# Altium schematic sheet Sheet1.SchDoc
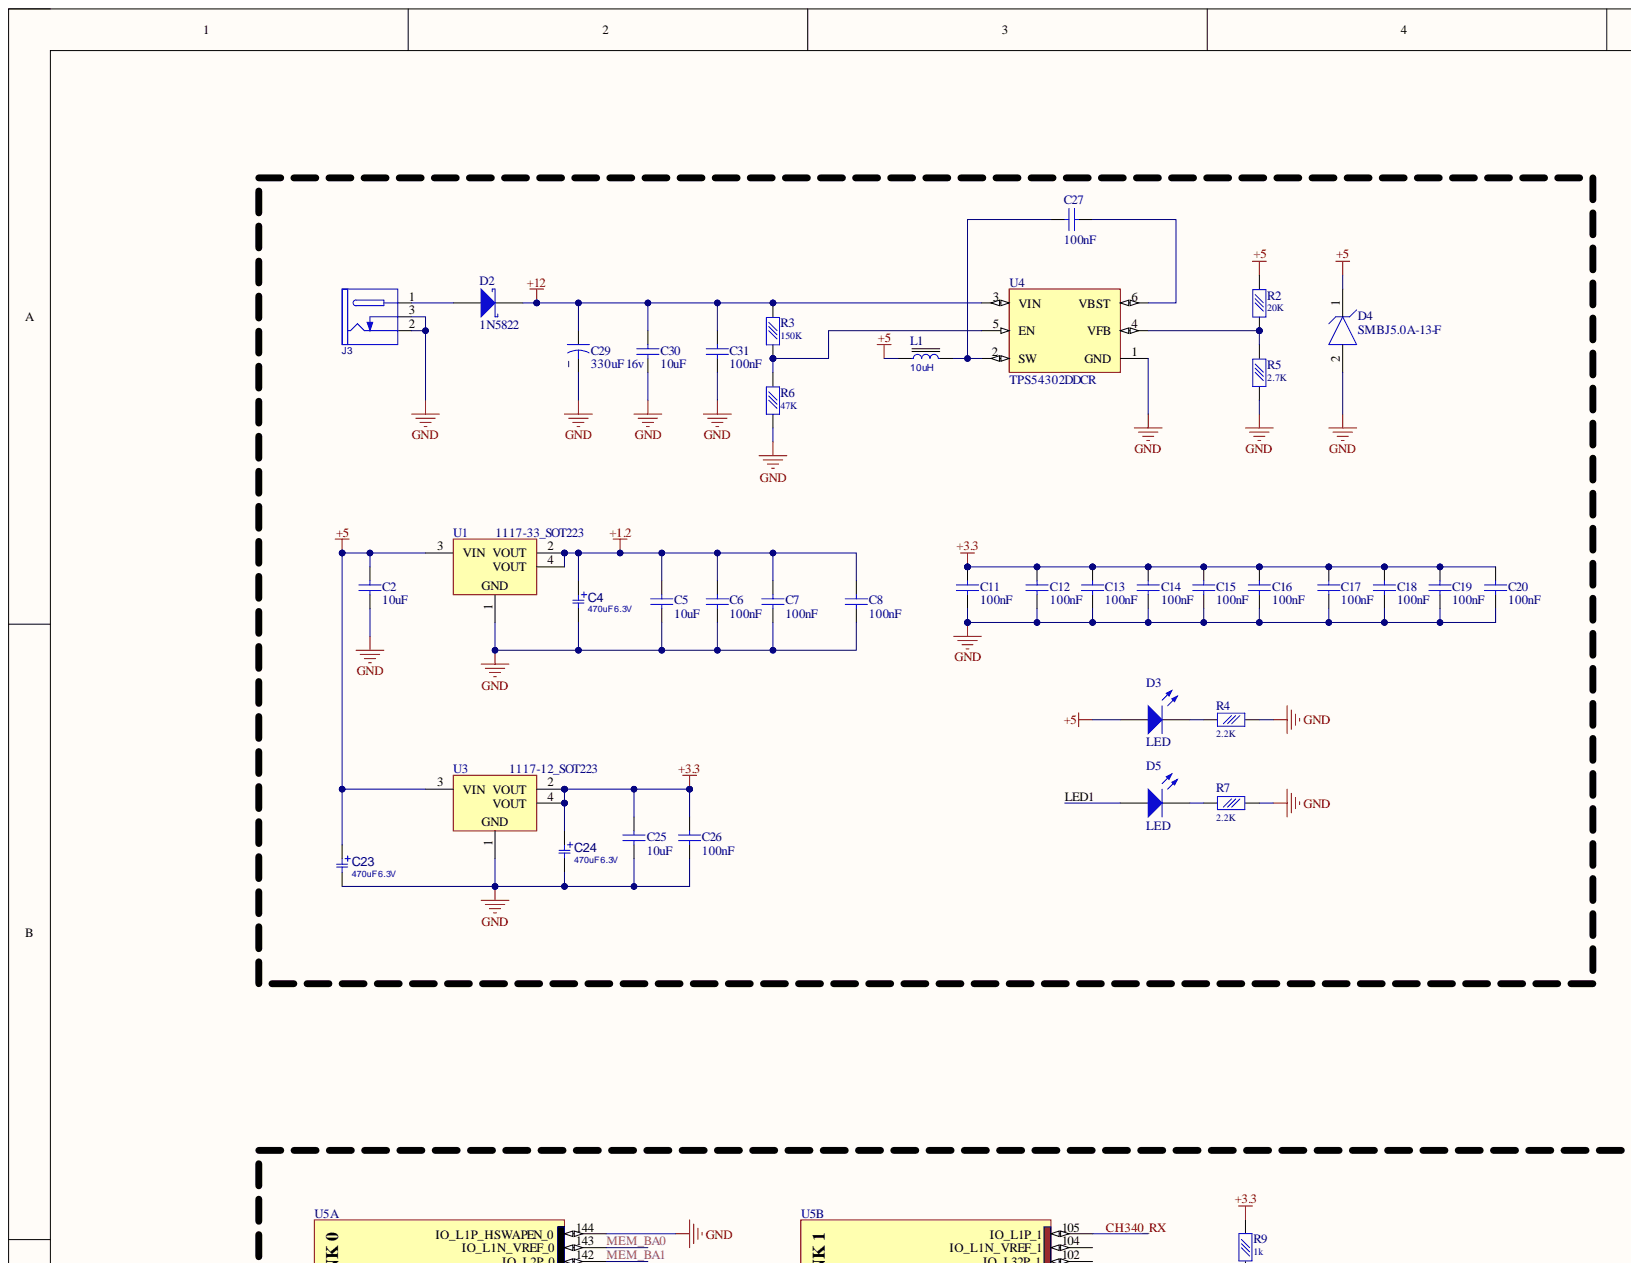
[diagram p0: Sheet1.SchDoc, top left]
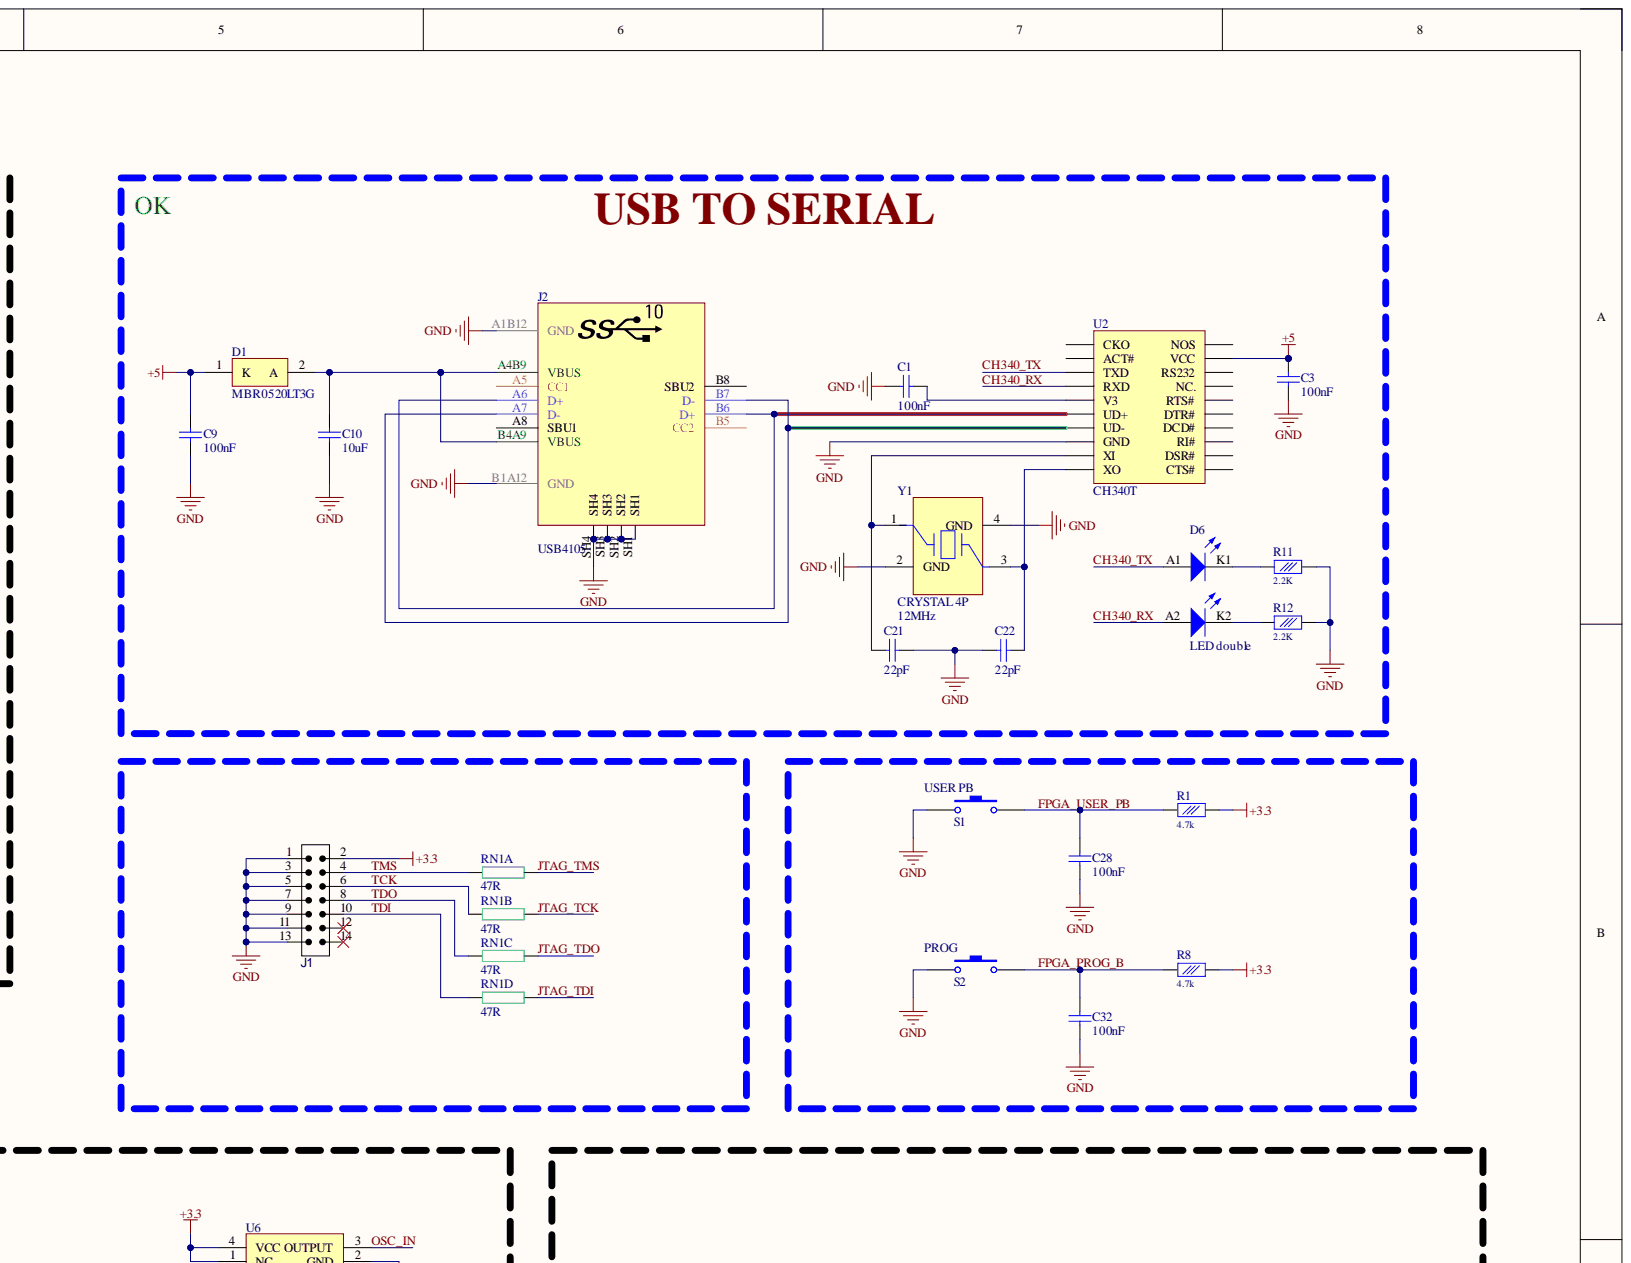
[diagram p1: Sheet1.SchDoc, top right]
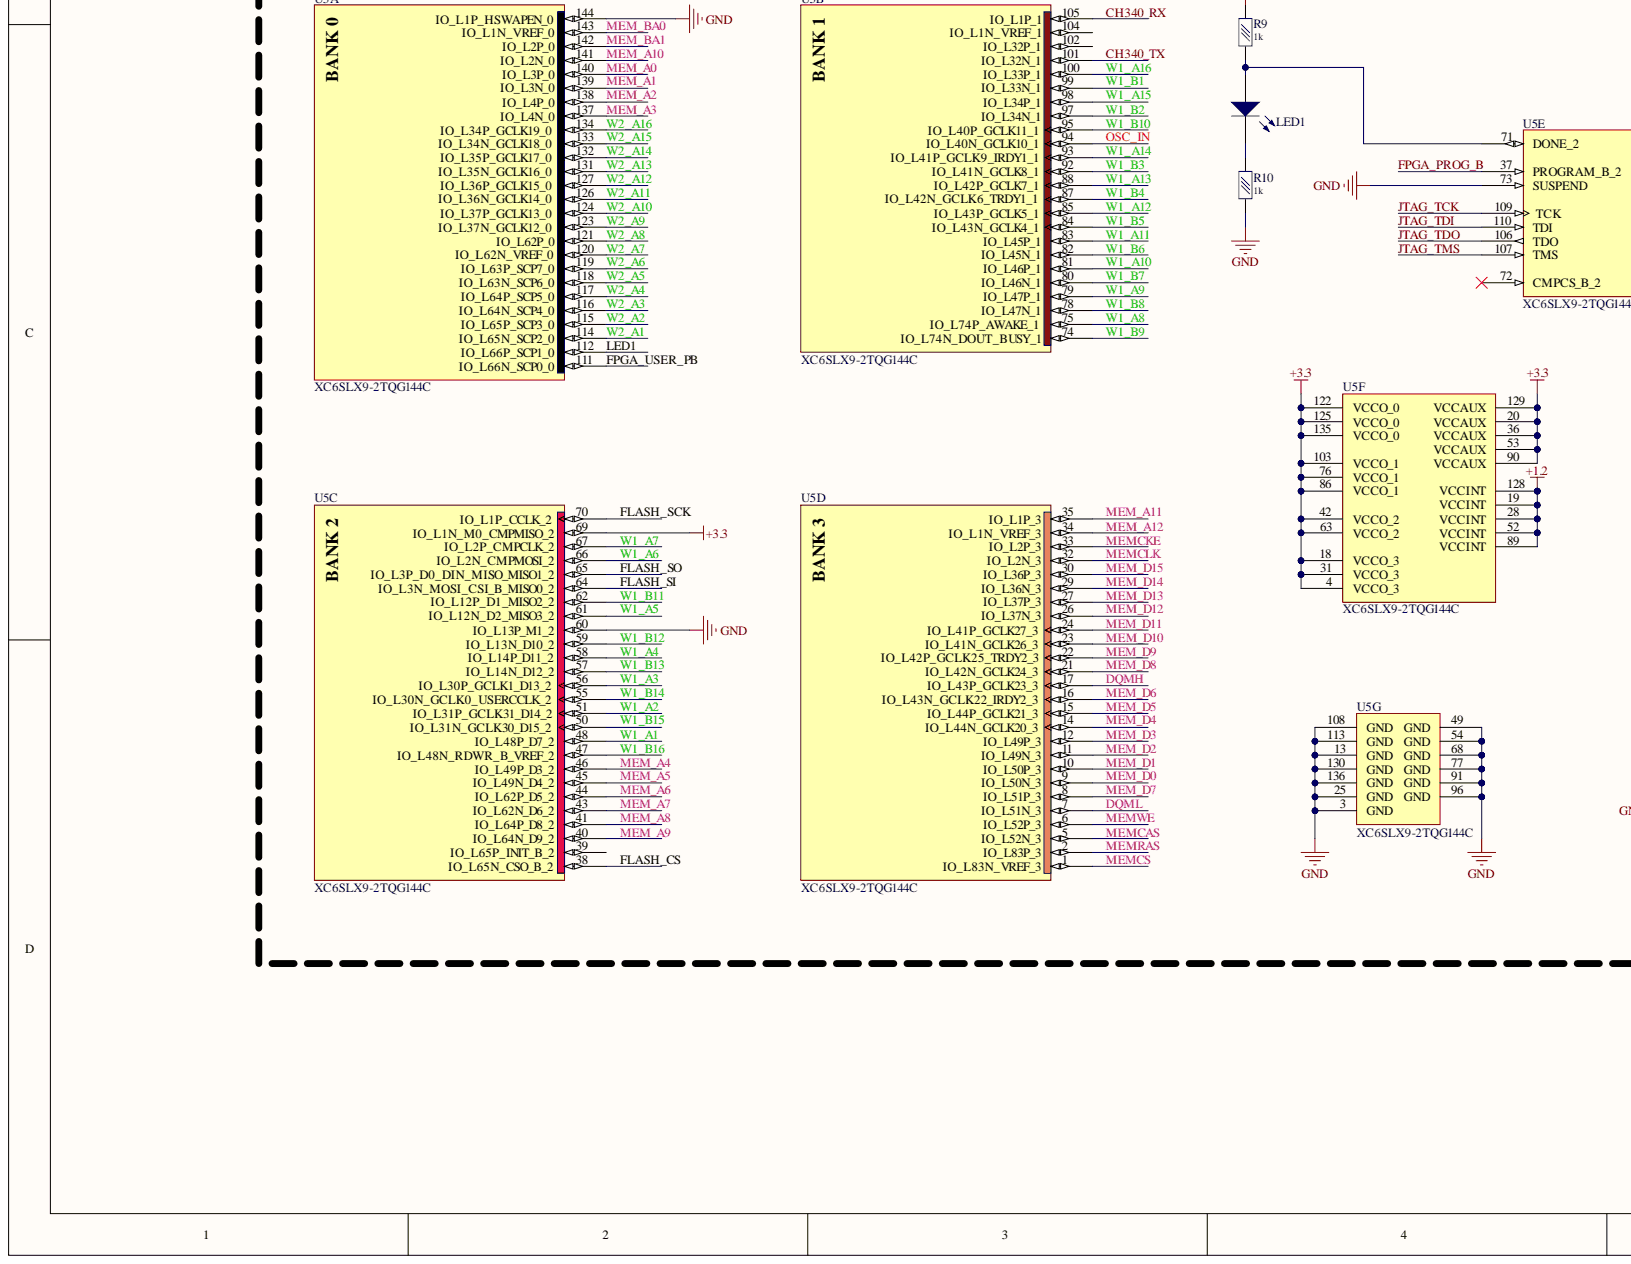
[diagram p2: Sheet1.SchDoc, bottom left]
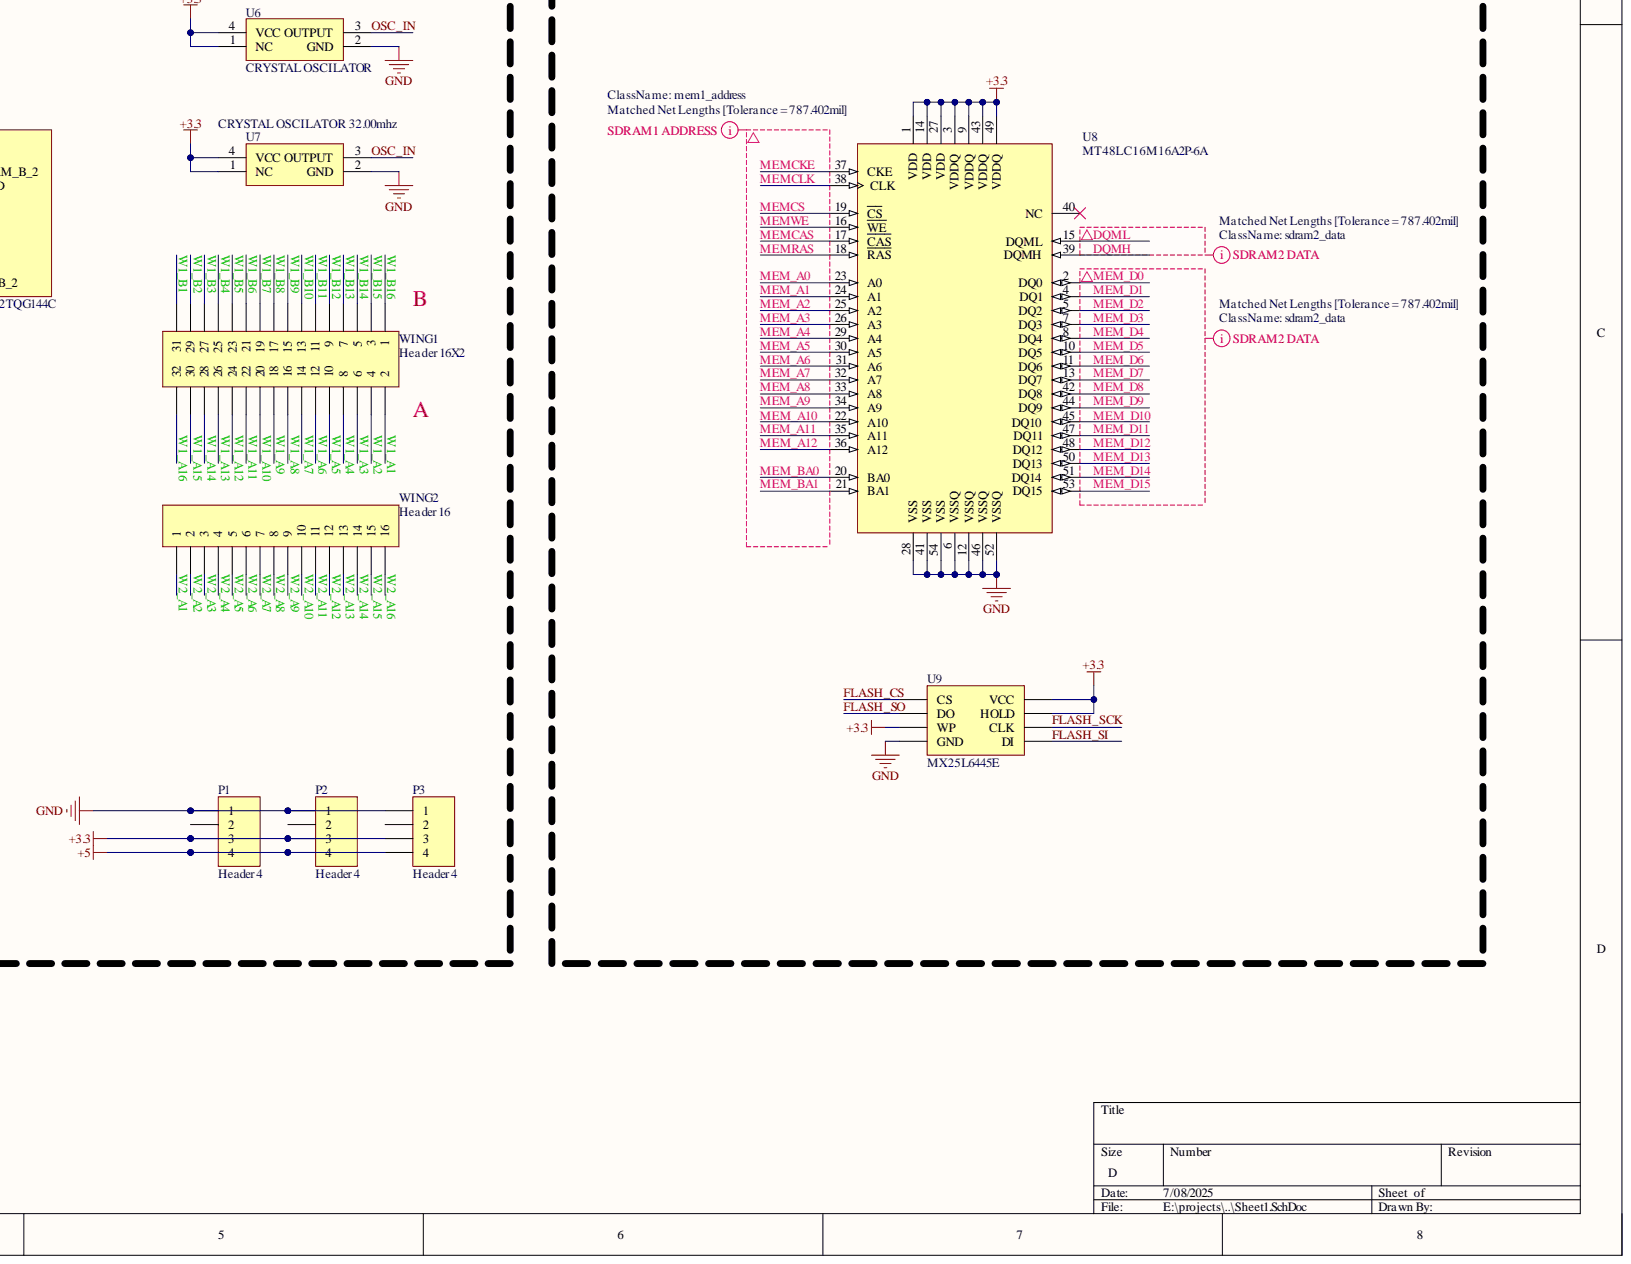
[diagram p3: Sheet1.SchDoc, bottom right]

## Parts
C1  value 100nF  [CAP 0805]  (hidden comment: chip_cap)
C2  value 10uF  [CAP 1206/3216 - 1.0MM]  (hidden comment: chip_cap)
C3  value 100nF  [CAP 0805]  (hidden comment: chip_cap)
C4  470uF 6.3V  [C_SOLID_6X11]
C5  value 10uF  [CAP 1206/3216 - 1.0MM]  (hidden comment: chip_cap)
C6  value 100nF  [0805]  (hidden comment: chip_cap)
C7  value 100nF  [0805]  (hidden comment: chip_cap)
C8  value 100nF  [CAP 0805]  (hidden comment: chip_cap)
C9  value 100nF  [CAP 0805]  (hidden comment: chip_cap)
C10  value 10uF  [CAP 1206/3216 - 1.0MM]  (hidden comment: chip_cap)
C11  value 100nF  [0805]  (hidden comment: chip_cap)
C12  value 100nF  [0805]  (hidden comment: chip_cap)
C13  value 100nF  [CAP 0805]  (hidden comment: chip_cap)
C14  value 100nF  [0805]  (hidden comment: chip_cap)
C15  value 100nF  [0805]  (hidden comment: chip_cap)
C16  value 100nF  [0805]  (hidden comment: chip_cap)
C17  value 100nF  [CAP 0805]  (hidden comment: chip_cap)
C18  value 100nF  [CAP 0805]  (hidden comment: chip_cap)
C19  value 100nF  [CAP 0805]  (hidden comment: chip_cap)
C20  value 100nF  [CAP 0805]  (hidden comment: chip_cap)
C21  value 22pF  [CAP 0603/1608]  (hidden comment: chip_cap)
C22  value 22pF  [CAP 0603/1608]  (hidden comment: chip_cap)
C23  470uF 6.3V  [C_SOLID_6X11]
C24  470uF 6.3V  [C_SOLID_6X11]
C25  value 10uF  [CAP 1206/3216 - 1.0MM]  (hidden comment: chip_cap)
C26  value 100nF  [0805]  (hidden comment: chip_cap)
C27  value 100nF  [CAP 0805]  (hidden comment: chip_cap)
C28  value 100nF  [0805]  (hidden comment: chip_cap)
C29  value 330uF 16v  [CAP-RADIAL-3.2mm]  (hidden comment: CAP)
C30  value 10uF  [CAP 1206/3216 - 1.0MM]  (hidden comment: chip_cap)
C31  value 100nF  [CAP 0805]  (hidden comment: chip_cap)
C32  value 100nF  [0805]  (hidden comment: chip_cap)
D1  MBR0520LT3G  [ONSC-SOD-123-2-425-04_V]
D2  1N5822  [DIOM5028X20N]
D3  LED  [LEDC1608X60N]
D4  SMBJ5.0A-13-F  [SMBJ_A]
D5  LED  [LEDC1608X60N]
D6  LED double  [LEDC1608X60N - double]
J1  IDC_2X7  [IDC_2X7]  (value not drawn)
J2  value USB4105  [USBC_SMD_16_USB4105]  (hidden comment: Debug Port)
J3  DC_POWER_JACK  [JAC_PWR2]  (value not drawn)
L1  10uH  [L_4]
LED1  LED  [LEDC1608X60N]
P1  Header 4  [Header1X4]
P2  Header 4  [Header1X4]
P3  Header 4  [Header1X4]
R1  value 4.7k  [0805]  (hidden comment: chip_res)
R2  value 20K  [RES 0603]  (hidden comment: chip_res)
R3  value 150K  [RES 0603]  (hidden comment: chip_res)
R4  value 2.2K  [RES 0805]  (hidden comment: chip_res)
R5  value 2.7K  [RES 0603]  (hidden comment: chip_res)
R6  value 47K  [RES 0603]  (hidden comment: chip_res)
R7  value 2.2K  [RES 0805]  (hidden comment: chip_res)
R8  value 4.7k  [0805]  (hidden comment: chip_res)
R9  value 1k  [0805]  (hidden comment: chip_res)
R10  value 1k  [0805]  (hidden comment: chip_res)
R11  value 2.2K  [RES 0603]  (hidden comment: chip_res)
R12  value 2.2K  [RES 0603]  (hidden comment: chip_res)
RN1  value 47R  [RESCAXS80P320X160X50-8N]  (hidden comment: ARRAY RES)  (parts A,B,C,D)
S1  USER PB  [tactile-micro-push-button]
S2  PROG  [tactile-micro-push-button]
U1  1117-33_SOT223  [SOT-223_M]
U2  CH340T  [SSOP20_L]
U3  1117-12_SOT223  [SOT-223_M]
U4  TPS54302DDCR  [FP-DDC0006A-IPC_B]
U5  XC6SLX9-2TQG144C  [TQG144_N]  (parts A,B,C,D,E,F,G)
U6  CRYSTAL OSCILATOR  [XTL_4_3225]
U7  CRYSTAL OSCILATOR 32.00mhz  [CRYSTAL OSCILLATOR]
U8  MT48LC16M16A2P-6A  [TSOP2-54_M]
U9  MX25L6445E  [8-pin SOIC 208-mil]
WING1  Header 16X2  [Header2X16]
WING2  Header 16  [Header1X16]
Y1  CRYSTAL 4P  [XTL_4_3225]

## Nets
+1.2: C4.1 C5.2 C6.2 C7.2 C8.2 U1.2(VOUT) U1.4(VOUT) U5.19(VCCINT) U5.28(VCCINT) U5.52(VCCINT) U5.89(VCCINT) U5.128(VCCINT)
+3.3: C11.2 C12.2 C13.2 C14.2 C15.2 C16.2 C17.2 C18.2 C19.2 C20.2 C24.1 C25.2 C26.2 J1.2 P1.3 P2.3 P3.3 R1.1 R8.1 R9.1 U3.2(VOUT) U3.4(VOUT) U5.4(VCCO_3) U5.18(VCCO_3) U5.20(VCCAUX) U5.31(VCCO_3) U5.36(VCCAUX) U5.42(VCCO_2) U5.53(VCCAUX) U5.63(VCCO_2) U5.69(IO_L1N_M0_CMPMISO_2) U5.76(VCCO_1) U5.86(VCCO_1) U5.90(VCCAUX) U5.103(VCCO_1) U5.122(VCCO_0) U5.125(VCCO_0) U5.129(VCCAUX) U5.135(VCCO_0) U6.1(NC) U6.4(VCC) U7.1(NC) U7.4(VCC) U8.1(VDD) U8.3(VDDQ) U8.9(VDDQ) U8.14(VDD) U8.27(VDD) U8.43(VDDQ) U8.49(VDDQ) U9.3(WP) U9.7(HOLD) U9.8(VCC)
+5: C2.2 C3.1 C9.2 C23.1 D1.1 D3.A D4.1 L1.1 P1.4 P2.4 P3.4 R2.2 U1.3(VIN) U2.19(VCC) U3.3(VIN)
+12: C29.1 C30.2 C31.2 D2.2 R3.2 U4.3(VIN)
GND: C1.2 C2.1 C3.2 C4.2 C5.1 C6.1 C7.1 C8.1 C9.1 C10.1 C11.1 C12.1 C13.1 C14.1 C15.1 C16.1 C17.1 C18.1 C19.1 C20.1 C21.2 C22.1 C23.2 C24.2 C25.1 C26.1 C28.1 C29.2 C30.1 C31.1 C32.1 D4.2 J1.1 J1.3 J1.5 J1.7 J1.9 J1.11 J1.13 J2.A1B12(GND) J2.B1A12(GND) J2.SH1 J2.SH2 J2.SH3 J2.SH4 J3.2 J3.3 P1.1 P2.1 P3.1 R4.2 R5.1 R6.1 R7.2 R10.2 R11.2 R12.2 S1.1 S2.1 U1.1 U2.8(GND) U3.1 U4.1(GND) U5.3(GND) U5.13(GND) U5.25(GND) U5.49(GND) U5.54(GND) U5.60(IO_L13P_M1_2) U5.68(GND) U5.73(SUSPEND) U5.77(GND) U5.91(GND) U5.96(GND) U5.108(GND) U5.113(GND) U5.130(GND) U5.136(GND) U5.144(IO_L1P_HSWAPEN_0) U6.2(GND) U7.2(GND) U8.6(VSSQ) U8.12(VSSQ) U8.28(VSS) U8.41(VSS) U8.46(VSSQ) U8.52(VSSQ) U8.54(VSS) U9.4(GND) Y1.2(GND) Y1.4(GND)
CH340_RX: D6.A2(K2) U2.4(RXD) U5.105(IO_L1P_1)
CH340_TX: D6.A1 U2.3(TXD) U5.101(IO_L32N_1)
DQMH: U5.17(IO_L43P_GCLK23_3) U8.39(DQMH)
DQML: U5.7(IO_L51N_3) U8.15(DQML)
FLASH_CS: U5.38(IO_L65N_CSO_B_2) U9.1(CS)
FLASH_SCK: U5.70(IO_L1P_CCLK_2) U9.6(CLK)
FLASH_SI: U5.64(IO_L3N_MOSI_CSI_B_MISO0_2) U9.5(DI)
FLASH_SO: U5.65(IO_L3P_D0_DIN_MISO_MISO1_2) U9.2(DO)
FPGA_PROG_B: C32.2 R8.2 S2.2 U5.37(PROGRAM_B_2)
FPGA_USER_PB: C28.2 R1.2 S1.2 U5.111(IO_L66N_SCP0_0)
JTAG_TCK: RN1.7 U5.109(TCK)
JTAG_TDI: RN1.5 U5.110(TDI)
JTAG_TDO: RN1.6 U5.106(TDO)
JTAG_TMS: RN1.8 U5.107(TMS)
LED1: D5.A U5.112(IO_L66P_SCP1_0)
MEM_A0: U5.140(IO_L3P_0) U8.23(A0)
MEM_A1: U5.139(IO_L3N_0) U8.24(A1)
MEM_A2: U5.138(IO_L4P_0) U8.25(A2)
MEM_A3: U5.137(IO_L4N_0) U8.26(A3)
MEM_A4: U5.46(IO_L49P_D3_2) U8.29(A4)
MEM_A5: U5.45(IO_L49N_D4_2) U8.30(A5)
MEM_A6: U5.44(IO_L62P_D5_2) U8.31(A6)
MEM_A7: U5.43(IO_L62N_D6_2) U8.32(A7)
MEM_A8: U5.41(IO_L64P_D8_2) U8.33(A8)
MEM_A9: U5.40(IO_L64N_D9_2) U8.34(A9)
MEM_A10: U5.141(IO_L2N_0) U8.22(A10)
MEM_A11: U5.35(IO_L1P_3) U8.35(A11)
MEM_A12: U5.34(IO_L1N_VREF_3) U8.36(A12)
MEM_BA0: U5.143(IO_L1N_VREF_0) U8.20(BA0)
MEM_BA1: U5.142(IO_L2P_0) U8.21(BA1)
MEM_D0: U5.9(IO_L50N_3) U8.2(DQ0)
MEM_D1: U5.10(IO_L50P_3) U8.4(DQ1)
MEM_D2: U5.11(IO_L49N_3) U8.5(DQ2)
MEM_D3: U5.12(IO_L49P_3) U8.7(DQ3)
MEM_D4: U5.14(IO_L44N_GCLK20_3) U8.8(DQ4)
MEM_D5: U5.15(IO_L44P_GCLK21_3) U8.10(DQ5)
MEM_D6: U5.16(IO_L43N_GCLK22_IRDY2_3) U8.11(DQ6)
MEM_D7: U5.8(IO_L51P_3) U8.13(DQ7)
MEM_D8: U5.21(IO_L42N_GCLK24_3) U8.42(DQ8)
MEM_D9: U5.22(IO_L42P_GCLK25_TRDY2_3) U8.44(DQ9)
MEM_D10: U5.23(IO_L41N_GCLK26_3) U8.45(DQ10)
MEM_D11: U5.24(IO_L41P_GCLK27_3) U8.47(DQ11)
MEM_D12: U5.26(IO_L37N_3) U8.48(DQ12)
MEM_D13: U5.27(IO_L37P_3) U8.50(DQ13)
MEM_D14: U5.29(IO_L36N_3) U8.51(DQ14)
MEM_D15: U5.30(IO_L36P_3) U8.53(DQ15)
MEMCAS: U5.5(IO_L52N_3) U8.17(CAS)
MEMCKE: U5.33(IO_L2P_3) U8.37(CKE)
MEMCLK: U5.32(IO_L2N_3) U8.38(CLK)
MEMCS: U5.1(IO_L83N_VREF_3) U8.19(CS)
MEMRAS: U5.2(IO_L83P_3) U8.18(RAS)
MEMWE: U5.6(IO_L52P_3) U8.16(WE)
OSC_IN: U5.94(IO_L40N_GCLK10_1) U6.3(OUTPUT) U7.3(OUTPUT)
TCK: J1.6 RN1.2
TDI: J1.10 RN1.4
TDO: J1.8 RN1.3
TMS: J1.4 RN1.1
W1_A1: U5.48(IO_L48P_D7_2) WING1.2
W1_A2: U5.51(IO_L31P_GCLK31_D14_2) WING1.4
W1_A3: U5.56(IO_L30P_GCLK1_D13_2) WING1.6
W1_A4: U5.58(IO_L14P_D11_2) WING1.8
W1_A5: U5.61(IO_L12N_D2_MISO3_2) WING1.10
W1_A6: U5.66(IO_L2N_CMPMOSI_2) WING1.12
W1_A7: U5.67(IO_L2P_CMPCLK_2) WING1.14
W1_A8: U5.75(IO_L74P_AWAKE_1) WING1.16
W1_A9: U5.79(IO_L47P_1) WING1.18
W1_A10: U5.81(IO_L46P_1) WING1.20
W1_A11: U5.83(IO_L45P_1) WING1.22
W1_A12: U5.85(IO_L43P_GCLK5_1) WING1.24
W1_A13: U5.88(IO_L42P_GCLK7_1) WING1.26
W1_A14: U5.93(IO_L41P_GCLK9_IRDY1_1) WING1.28
W1_A15: U5.98(IO_L34P_1) WING1.30
W1_A16: U5.100(IO_L33P_1) WING1.32
W1_B1: U5.99(IO_L33N_1) WING1.31
W1_B2: U5.97(IO_L34N_1) WING1.29
W1_B3: U5.92(IO_L41N_GCLK8_1) WING1.27
W1_B4: U5.87(IO_L42N_GCLK6_TRDY1_1) WING1.25
W1_B5: U5.84(IO_L43N_GCLK4_1) WING1.23
W1_B6: U5.82(IO_L45N_1) WING1.21
W1_B7: U5.80(IO_L46N_1) WING1.19
W1_B8: U5.78(IO_L47N_1) WING1.17
W1_B9: U5.74(IO_L74N_DOUT_BUSY_1) WING1.15
W1_B10: U5.95(IO_L40P_GCLK11_1) WING1.13
W1_B11: U5.62(IO_L12P_D1_MISO2_2) WING1.11
W1_B12: U5.59(IO_L13N_D10_2) WING1.9
W1_B13: U5.57(IO_L14N_D12_2) WING1.7
W1_B14: U5.55(IO_L30N_GCLK0_USERCCLK_2) WING1.5
W1_B15: U5.50(IO_L31N_GCLK30_D15_2) WING1.3
W1_B16: U5.47(IO_L48N_RDWR_B_VREF_2) WING1.1
W2_A1: U5.114(IO_L65N_SCP2_0) WING2.1
W2_A2: U5.115(IO_L65P_SCP3_0) WING2.2
W2_A3: U5.116(IO_L64N_SCP4_0) WING2.3
W2_A4: U5.117(IO_L64P_SCP5_0) WING2.4
W2_A5: U5.118(IO_L63N_SCP6_0) WING2.5
W2_A6: U5.119(IO_L63P_SCP7_0) WING2.6
W2_A7: U5.120(IO_L62N_VREF_0) WING2.7
W2_A8: U5.121(IO_L62P_0) WING2.8
W2_A9: U5.123(IO_L37N_GCLK12_0) WING2.9
W2_A10: U5.124(IO_L37P_GCLK13_0) WING2.10
W2_A11: U5.126(IO_L36N_GCLK14_0) WING2.11
W2_A12: U5.127(IO_L36P_GCLK15_0) WING2.12
W2_A13: U5.131(IO_L35N_GCLK16_0) WING2.13
W2_A14: U5.132(IO_L35P_GCLK17_0) WING2.14
W2_A15: U5.133(IO_L34N_GCLK18_0) WING2.15
W2_A16: U5.134(IO_L34P_GCLK19_0) WING2.16
NetC1_1: C1.1 U2.5(V3)
NetC10_2: C10.2 D1.2 J2.A4B9(VBUS) J2.B4A9(VBUS)
NetC21_1: C21.1 U2.9(XI) Y1.1
NetC22_2: C22.2 U2.10(XO) Y1.3
NetC27_1: C27.1 L1.2 U4.2(SW)
NetC27_2: C27.2 U4.6(VBST)
NetD2_1: D2.1 J3.1
NetD3_K: D3.K R4.1
NetD5_K: D5.K R7.1
NetD6_K1: D6.K1 R11.1
NetD6_K2: D6.K2(A2) R12.1
NetJ2_A6: J2.A6(D+) J2.B6(D+) U2.6(UD+)
NetJ2_A7: J2.A7(D-) J2.B7(D-) U2.7(UD-)
NetLED1_A: LED1.A R9.2 U5.71(DONE_2)
NetLED1_K: LED1.K R10.1
NetR2_1: R2.1 R5.2 U4.4(VFB)
NetR3_1: R3.1 R6.2 U4.5(EN)
USB TO SERIAL:

Not connected: J1 12 14; J2 A5(CC1) A8(SBU1) B5(CC2) B8(SBU2); P1 2; P2 2; P3 2; U2 1(CKO) 2(ACT#) 11(CTS#) 12(DSR#) 13(RI#) 14(DCD#) 15(DTR#) 16(RTS#) 17(NC.) 18(RS232) 20(NOS); U5 39(IO_L65P_INIT_B_2) 72(CMPCS_B_2) 102(IO_L32P_1) 104(IO_L1N_VREF_1); U8 40(NC)

## Library data of the parts
C1-C3 C5-C22 C25-C28 C30-C32 = chip_cap: Capacitor (Semiconductor SIM Model); Component Kind: Standard; LatestRevisionDate: 29-May-2009; LatestRevisionNote: IPC-7351 Footprint Added.; PackageDescription: Chip Capacitor; PackageReference: C1206; Published: 8-Jun-2000; Publisher: Altium Limited
  C1-C3 C5 C9 C10 C13 C21 C22 C25 C27 C30 C31: Library Name: @mylib_passive.IntLib
  C6 C7 C11 C12 C14-C16 C26 C28 C32: Library Name: my_lib.IntLib
  C8 C17-C20: Library Name: @my_lib.IntLib
C4 C23 C24 = CAP_THD_SOLID_6X11: CAP, THD, ELEC,; DESC: DESCRIPTION; DIST#: MOUSER; MANF_P#: MANF_PART#; PARAM: 20%; PARAM2: 25V; ASSEMBLY: ASSEMBLY; Component Kind: Standard; Library Name: @mylib_passive.IntLib; MANF: MANF; PRICE: 10= 5$; VALUE: 470uF 6.3V
C29 = CAP RADIAL: Value: 330uF 16v; Component Kind: Standard; Library Name: @mylib_passive.IntLib
D1 = CMP-1055-00408-1: Schottky Power Rectifier, 2-Pin SOD-123, Pb-Free, Tape and Reel; Manufacturer: On Semiconductor; Configuration: Single; IFSM Max (A): 5.5; Component Kind: Standard; ComponentLink1Description: Manufacturer URL; ComponentLink1URL: http://www.onsemi.com/; ComponentLink2Description: Datasheet; ComponentLink2URL: http://www.onsemi.cn/pub_link/Collateral/MBR0520LT1-D.PDF; ComponentLink3Description: Package Specification; ComponentLink3URL: http://www.onsemi.com/pub_link/Collateral/425-04.PDF; DatasheetVersion: Rev. 6; Library Name: Altium Content Vault; Mounting Technology: Surface Mount; PackageDescription: 2-Pin SOD, Body 1.6 x 2.69 mm; PackageReference: SOD-123-2-425-04; PackageVersion: Rev. G, 10/2009; Packing: Tape and Reel; PartNumber: MBR0520LT3G; RoHS: TRUE
D2 = 1N5822: Silicon RF Schottky Diode for Mixer Applications in the VHF/UHF Range; Component Kind: Standard; Library Name: @my diode.IntLib; PackageReference: SMC; PackageVersion: Sep-2001; Published: 21-Mar-2003; Publisher: Altium Limited
D3 D5 LED1 = LED: Typical RED GaAs LED; Component Kind: Standard; LatestRevisionDate: 08-Jul-2005; LatestRevisionNote: Mech Layer 1 removed.; Library Name: my_lib.IntLib; PackageDescription: LED; 2 Leads; PackageReference: LED-1; Published: 8-Jun-2000; Publisher: Altium Limited
D4 = SMBJ5.0A: TVS DIODE 5V 6.5V SMB; Manufacturer: Diodes Inc; Breakdown Voltage: 6.4V; Clamping Voltage: 9.2V; Direction: Unidirectional; Max Junction Temp: +150°C; Number Of Channels: 1; Peak Pulse Current: 65.2A; Peak Pulse Power: 600W; Standoff Height: 0.05mm; Automotive: No; Category: Diode; Component Kind: Standard; ComponentLink2Description: Datasheet; ComponentLink2URL: https://www.diodes.com/assets/Datasheets/ds19002.pdf; Device Class L1: Circuit Protection; Device Class L2: TVS Diodes; Device Class L3: unset; Digikey Description: TVS DIODE 5V 9.2V SMB; Footprint Url: https://www.diodes.com/assets/Package-Files/SMB.pdf; Height: 2.5mm; Lead Free: Yes; Library Name: @my diode.IntLib; Manufacturer Part Number: SMBJ5.0A-13-F; Mouser Description: TVS Diodes - Transient Voltage Suppressors 600W 5.0V; Octopart: https://octopart.com/smbj5.0a-13-f-diodes+inc.-350946; Package: SMB; Reverse Standoff Voltage: 5V; RoHS: TRUE; Temperature: -55°C to +150°C; Temperature Range High: +150°C; Temperature Range Low: -55°C
D6 = LED double: Typical RED GaAs LED; Component Kind: Standard; LatestRevisionDate: 08-Jul-2005; LatestRevisionNote: Mech Layer 1 removed.; Library Name: @my_lib.IntLib; PackageDescription: LED; 2 Leads; PackageReference: LED-1; Published: 8-Jun-2000; Publisher: Altium Limited
J1 = IDC_2X7: CONN, IDC, Male, pitch: 2.54mm, 14POS; Component Kind: Standard; Library Name: conn_header_2.54mm_box_idc.SchLib; VALUE: IDC_2X7
J2 = CONN_USBC_SMD_16_USB4105: CONN, USB, Type C, USB 2.0, Right-Angle, SMD; MANF: GCT; MANF_P#: USB4105-GF-A; PARAM: 5A; Component Kind: Standard; Library Name: conn_usbc.SchLib; Price: 1.5$
J3 = CONN_PWR_JACKR2: CONN, PWR JACK DC, PLUG DIAM 2.0MM RA THD; MANF: CUI STACK; MANF_P#: PJ-202A, PJ-002AH, PJ-102AH, KLDX-0202; Component Kind: Standard; Library Name: conn_powerJack.SchLib; VALUE: DC_POWER_JACK
L1 = INDPWR_SMD: INDUCTOR, POWER, SMD; Component Kind: Standard; Library Name: passive_smd.SchLib; VALUE: 10uH
P1-P3 = Header 4: Header, 4-Pin; Component Kind: Standard; LatestRevisionDate: 17-Jul-2002; LatestRevisionNote: Re-released for DXP Platform.; Library Name: @Connector.IntLib; Publisher: Altium Limited
R1-R12 = chip_res: Resistor; Component Kind: Standard; LatestRevisionDate: 29-May-2009; LatestRevisionNote: IPC-7351 Footprint Added.; PackageDescription: Chip Resistor; PackageReference: J1-0603; Published: 8-Jun-2000; Publisher: Altium Limited
  R1 R8-R10: Library Name: my_lib.IntLib
  R2-R7 R11 R12: Library Name: @mylib_passive.IntLib
S1 S2 = tactile-micro-push-button: Switch; Component Kind: Standard; LatestRevisionDate: 17-Jul-2002; LatestRevisionNote: Re-released for DXP Platform.; Library Name: @my-switch.IntLib; PackageDescription: Switch; 2 Leads; PackageReference: SPST-2; Published: 24-Mar-1999; Publisher: Altium Limited
U1 U3 = 1117-33_SOT223: 1A, Low Drop Positive Voltage Regulator, 1.2V, 4-Pin SOT-223, Tape and Reel; PartNumber: LD1117AS12TR; Component Kind: Standard; ComponentLink1Description: Manufacturer URL; ComponentLink1URL: http://www.st.com; ComponentLink2Description: Datasheet; ComponentLink2URL: http://www.st.com/internet/com/TECHNICAL_RESOURCES/TECHNICAL_LITERATURE/DATASHEET/CD00002116.pdf; DatasheetVersion: Dec-2011; Library Name: my_lib.IntLib; PackageDescription: 4-Pin SOT-223 Package, 6.5 x 3.5 mm Body, 2.3 mm Pitch, 7 mm Lead Span; PackageReference: SOT-223; PackageVersion: 2010
U4 = TPS562201DDCR: IC REG BUCK ADJ 2A TSOT23-6; Max Input Voltage: 17V; Min Input Voltage: 4.5V; Number of Outputs: 1; Output Type: Adjustable; Output Voltage: 7V; Quiescent Current: 400uA; Switching Frequency: 580kHz; Case/Package: SOT; Component Kind: Standard; Contact Plating: Tin; Lead Free: Lead Free; Library Name: @my-lib-regulator.IntLib; Max Operating Temperature: 125°C; Max Output Current: 2A; Min Operating Temperature: -40°C; Mount: Surface Mount; Number of Pins: 6; Operating Supply Voltage: 17V; RoHS Compliant: Yes
U5 = XC6SLX9-2TQG144C: Spartan-6 LX 1.2V FPGA, 102 User I/Os, 144-Pin TQFP (0.5mm Pitch), Speed Grade 2, Commercial Grade, Pb-Free; Code_JEDEC: MS-026-BFB; Component Kind: Standard; ComponentLink1Description: Manufacturer URL; ComponentLink1URL: http://www.xilinx.com/; ComponentLink2Description: Spartan-6 Datasheet; ComponentLink2URL: http://www.xilinx.com/support/documentation/data_sheets/ds160.pdf; ComponentLink3Description: Spartan-6 Documents; ComponentLink3URL: http://www.xilinx.com/support/documentation/spartan-6.htm; DatasheetVersion: ver1.6, Nov-2010; Library Name: Xilinx Spartan-6.IntLib; PackageDescription: 144-Pin Thin Quad Flat Pack (TQG144) - Pb-Free; PackageReference: TQG144; PackageVersion: ver1.2, Jun-2004
U7 = CRYSTAL OSCILATOR: Component Kind: Standard; Library Name: my_lib.IntLib
U8 = MT48LC16M16A2P-6A: 256Mb Synchronous High-Speed CMOS DRAM, 16Mb x 16Bit x 4 Banks, 54-Pin TSOP Pb-free, Commercial; ColumnAddress: 512 (A0-A8); CycleTime: 6.0ns @ CL = 3; RowAddress: 8K (A0-A12); Code_JEDEC: MS-024-FA; Component Kind: Standard; ComponentLink1Description: Manufacturer Link; ComponentLink1URL: http://www.micron.com; ComponentLink2Description: Datasheet; ComponentLink2URL: http://download.micron.com/pdf/datasheets/dram/sdram/256MSDRAM.pdf; DatasheetVersion: RevL, Oct-2007; LatestRevisionDate: 13-Apr-2006; LatestRevisionNote: IPC-7351 Footprint Added.; Library Name: Micron Memory Dynamic RAM.IntLib; PackageDescription: 54-Pin Plastic Small Outline Package 0.8 mm Pitch; PackageReference: TSOP2-54; PackageVersion: Sep-2003; Published: 11-Oct-2004; Publisher: Altium Limited
U9 = W25QXX: WINBOAND SPI FLASH; Component Kind: Standard; Library Name: my_lib.IntLib
WING1 = Header 16X2: Header, 16-Pin, Dual row; Component Kind: Standard; LatestRevisionDate: 17-Jul-2002; LatestRevisionNote: Re-released for DXP Platform.; Library Name: Connector.IntLib; Publisher: Altium Limited
WING2 = Header 16: Header, 16-Pin; Component Kind: Standard; LatestRevisionDate: 17-Jul-2002; LatestRevisionNote: Re-released for DXP Platform.; Library Name: Connector.IntLib; Publisher: Altium Limited
Y1 = CRYSTAL SMD 4P: CRYSTAL 4P-24MHZ 8PF SMD; ESR (Equivalent Series Resistance): 80R; Frequency: 12MHz; Frequency Stability: 30ppm; Frequency Tolerance: 20ppm; Case/Package: SMD/SMT; Component Kind: Standard; Height: 0.035inch; Length: 0.126inch; Library Name: @my_lib.IntLib; Load Capacitance: 8pF; Max Operating Temperature: 85°C; Min Operating Temperature: -40°C; Mount: Surface Mount; Number of Pins: 4; Package Quantity: 3000; Packaging: Tape and Reel; Width: 0.098inch
RN1 = ARRAY RES: Component Kind: Standard; Library Name: @mylib_passive.IntLib
U2 = CH340T: Component Kind: Standard; Library Name: my_lib.IntLib
U6 = CRYSTAL OSCILATOR: Component Kind: Standard; Library Name: @my_lib.IntLib
